# Altium schematic sheet LoRa_Elemon_3.SchDoc(LoRa_Elemon_3)
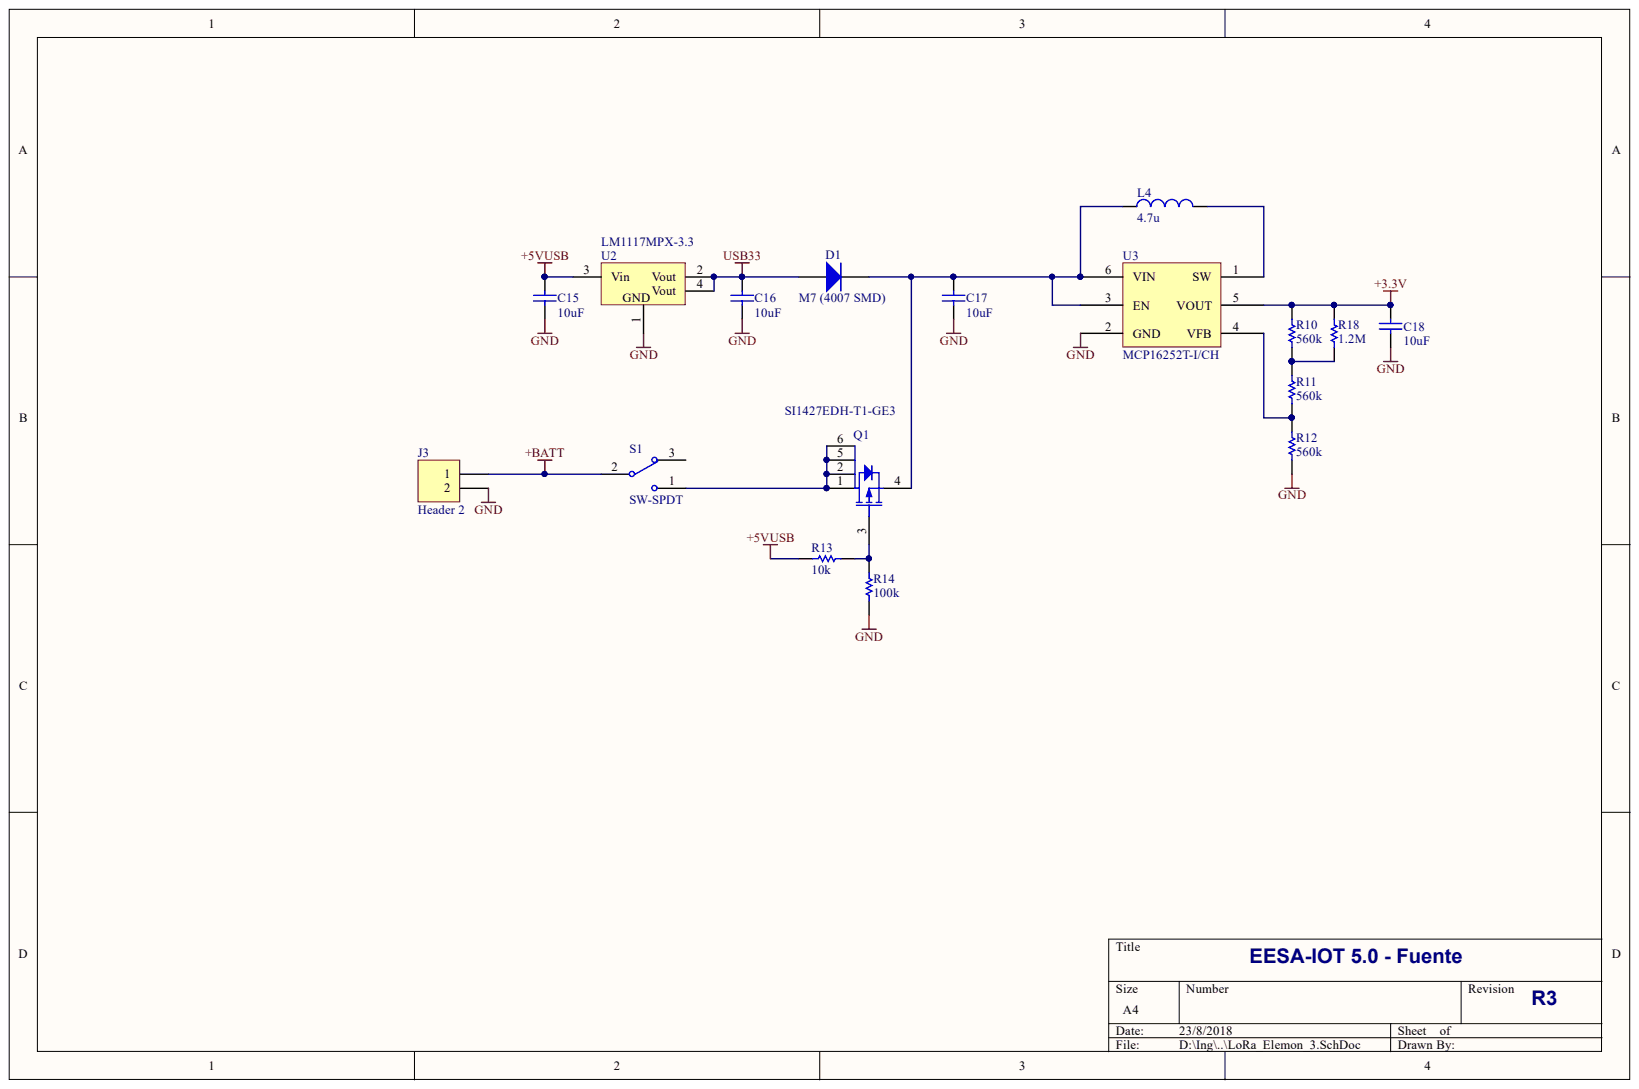
[diagram p0: LoRa_Elemon_3.SchDoc]

## Parts
C15  10uF  [1206]
C16  10uF  [1206]
C17  10uF  [1206]
C18  10uF  [1206]
D1  M7 (4007 SMD)  [SMA]
J3  Header 2  [136-02ST]
L4  4.7u  [1007]
Q1  SI1427EDH-T1-GE3  [SC-70]
R10  560k  [0805]
R11  560k  [0805]
R12  560k  [0805]
R13  10k  [0805]
R14  100k  [0805]
R18  1.2M  [0805]
S1  SW-SPDT  [SWITCH-CL-SB-12B]
U2  LM1117MPX-3.3  [DSO-G3/C6.6]
U3  MCP16252T-I/CH  [SOT-23-6]

## Nets
+3.3V: C18.2 R10.1 R18.2 U3.5(VOUT)
+5VUSB: C15.2 R13.2 U2.3(Vin)
+BATT: J3.1 S1.2
GND: C15.1 C16.1 C17.1 C18.1 J3.2 R12.2 R14.1 U2.1 U3.2(GND)
USB33: C16.2 D1.1 U2.2(Vout) U2.4(Vout)
NetC17_2: C17.2 D1.2 L4.2 Q1.4 U3.3(EN) U3.6(VIN)
NetL4_1: L4.1 U3.1(SW)
NetQ1_1: Q1.1 Q1.2 Q1.5 Q1.6 S1.1
NetQ1_3: Q1.3 R13.1 R14.2
NetR10_2: R10.2 R11.2 R18.1
NetR11_1: R11.1 R12.1 U3.4(VFB)

Not connected: S1 3

## Library data of the parts
C15-C18 = Cap: Capacitor; Value: 100pF; Component Kind: Standard; Library Name: Miscellaneous Devices.IntLib; Published: 8-Jun-2000; Revision: July-2002: Re-released for DXP Platform.; Signal Integrity: Cap; Simulation: CAP; Publisher: Altium Limited
D1 = Diode: Default Diode; Code_JEDEC: DO-214-AB; Component Kind: Standard; LatestRevisionDate: 17-Jul-2002; LatestRevisionNote: Re-released for DXP Platform.; Library Name: Miscellaneous Devices.IntLib; PackageDescription: DO-214-AB/SMC; 2 C-Bend Leads; Body 7.9 x 5.9 mm, inc. leads (LxW); PackageReference: SMC; PackageVersion: Sep-1996; Published: 8-Jun-2000; Signal Integrity: Diode; Simulation: DIODE; Publisher: Altium Limited
J3 = Header 2: Header, 2-Pin; Component Kind: Standard; LatestRevisionDate: 17-Jul-2002; LatestRevisionNote: Re-released for DXP Platform.; Library Name: Miscellaneous Connectors.IntLib; Signal Integrity: Connector; Publisher: Altium Limited
L4 = Inductor: Code_IEC: 1005; Value: 10mH; Code_JEITA: 0402; Component Kind: Standard; Description: Inductor; LatestRevisionDate: 29-May-2009; LatestRevisionNote: IPC-7351 Footprint Added.; Library Name: Miscellaneous Devices.IntLib; PackageDescription: Chip Inductor; PackageReference: 0402-A; Published: 8-Jun-2000; Signal Integrity: Inductor; Simulation: INDUCTOR; Publisher: Altium Limited
Q1 = SI1427E: P-Channel MOSFET; Component Kind: Standard; LatestRevisionDate: 17-Jul-2002; LatestRevisionNote: Re-released for DXP Platform.; PackageDescription: TO, Flat Index; 3 In-Line, Axial Leads; Body Dia. 4.6mm; Lead Dia. 0.5 mm (max); PackageReference: E3; PackageVersion: 1995/1996; Published: 8-Jun-2000; Simulation: PMOS; Library Name: LoRa_Elemon.SchLib; Publisher: Altium Limited
R10-R14 R18 = Res1: Resistor SMD 0805; Value: 1K; Component Kind: Standard; LatestRevisionDate: 17-Jul-2002; LatestRevisionNote: Re-released for DXP Platform.; Library Name: Miscellaneous Devices.IntLib; PackageDescription: Axial Device, Thru-Hole; 2 Leads; 0.3 in Pin Spacing; PackageReference: AXIAL-0.3; Published: 8-Jun-2000; Signal Integrity: Res; Simulation: RESISTOR; Publisher: Altium Limited
S1 = SW-SPDT: SPDT Subminiature Toggle Switch, Right Angle Mounting, Vertical Actuation; Component Kind: Standard; ComponentLink1Description: Manufacturer Link; ComponentLink1URL: http://www.apem.com; DatasheetVersion: 05-Dec-2000; LatestRevisionDate: 17-Dec-2003; LatestRevisionNote: Schematic revised, PCB Footprint replaced.; Library Name: Miscellaneous Devices.IntLib; PackageDescription: Switch; 4 Leads; PackageReference: TL36WW15050; Published: 24-Mar-1999; Publisher: Altium Limited
U2 = LM1117MPX: Voltage Regulator; Code - JEDEC: SMD-220; Component Kind: Standard; Library Name: gen500.SCHLIB; Published: 24-Mar-1999; Revision: July-2002: Re-released for DXP Platform.; Publisher: Altium Limited
U3 = MCP16252: Component Kind: Standard; Library Name: LoRa_Elemon.SchLib
